# Altium schematic sheet 419-005-01-02_PG 11 - USBs.SchDoc("419-005-01-02_PG 11 - USBs")
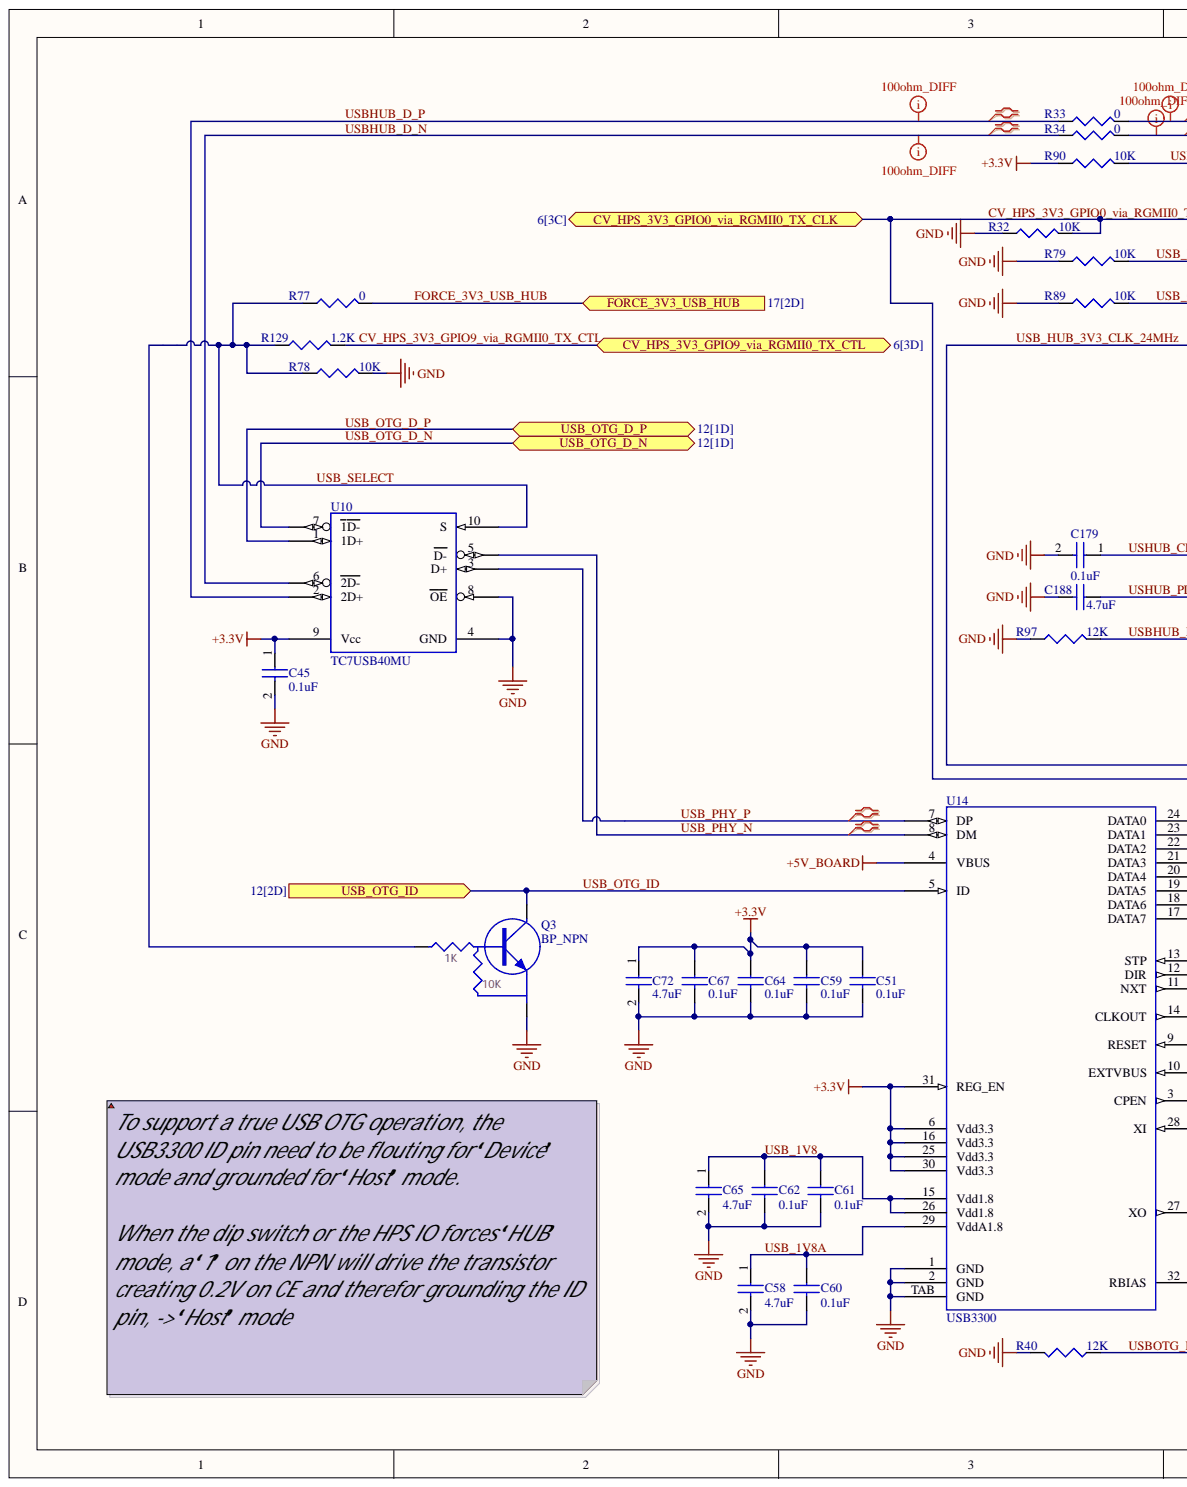
[diagram p0: 419-005-01-02_PG 11 - USBs.SchDoc, left]
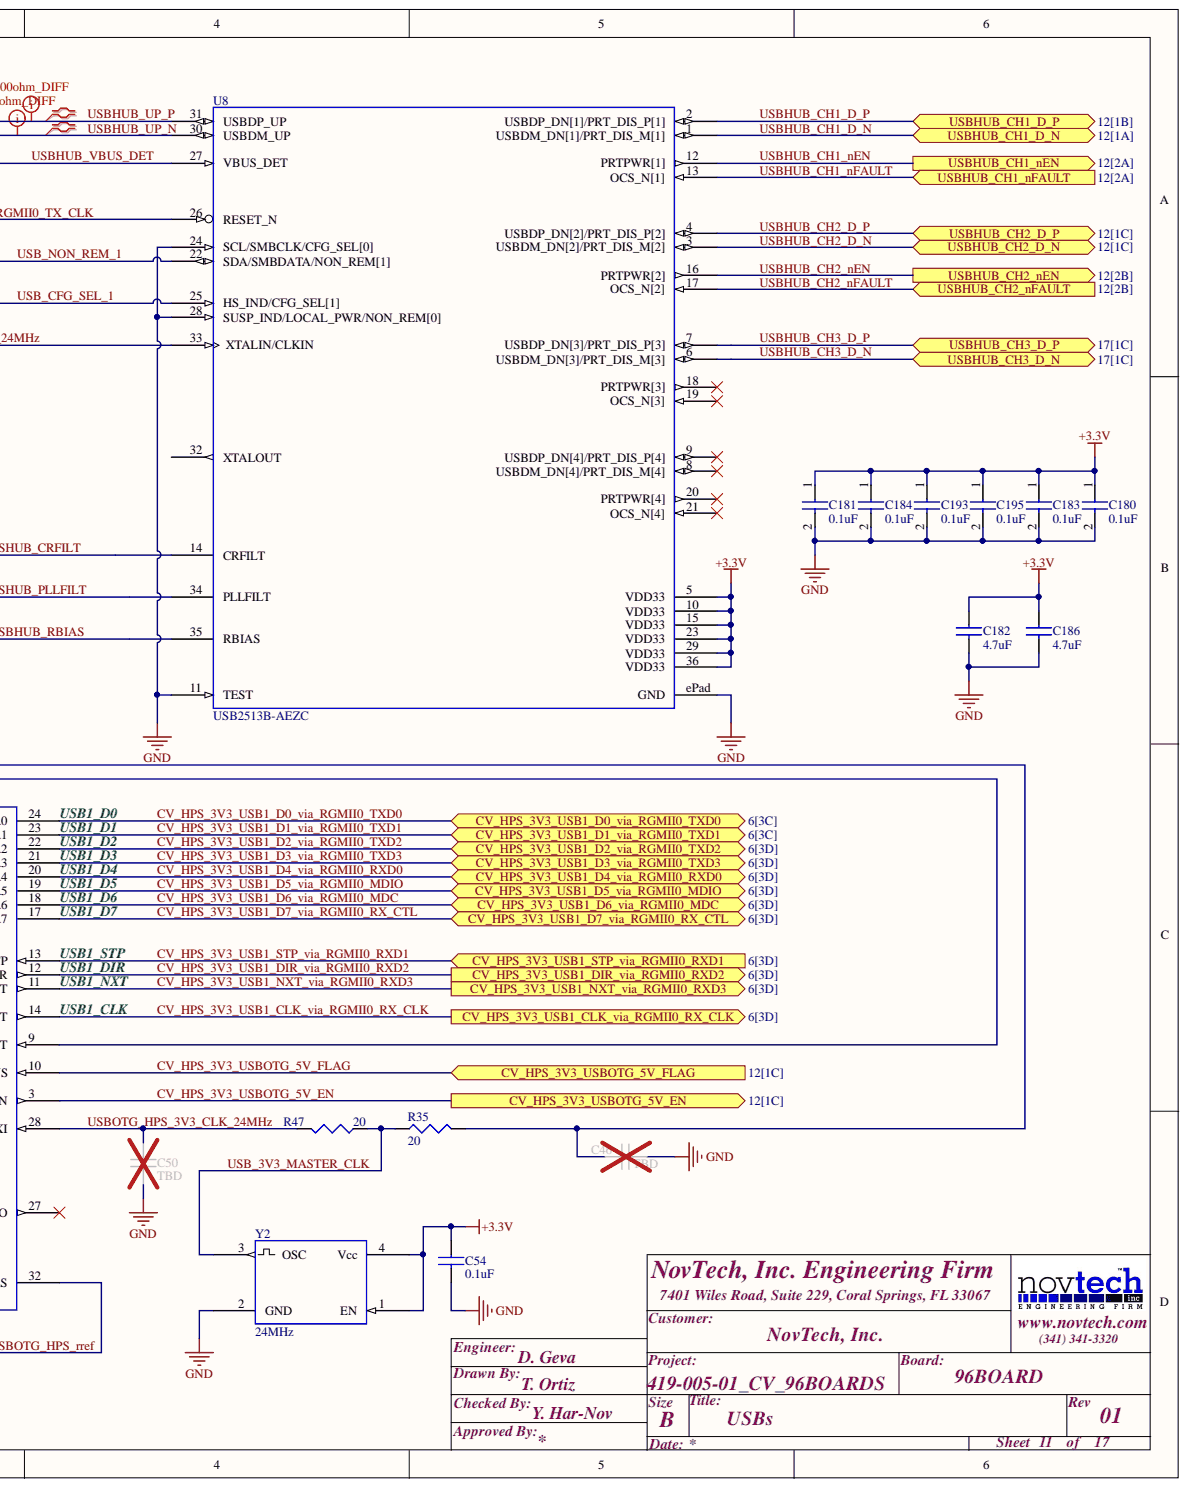
[diagram p1: 419-005-01-02_PG 11 - USBs.SchDoc, right]

## Parts
C45  0.1uF  [0201_C]
C46  TBD  [0402_C]
C50  TBD  [0402_C]
C51  0.1uF  [0201_C]
C54  0.1uF  [0201_C]
C58  4.7uF  [0402_C]
C59  0.1uF  [0201_C]
C60  0.1uF  [0201_C]
C61  0.1uF  [0201_C]
C62  0.1uF  [0201_C]
C64  0.1uF  [0201_C]
C65  4.7uF  [0402_C]
C67  0.1uF  [0201_C]
C72  4.7uF  [0402_C]
C179  0.1uF  [0201_C]
C180  0.1uF  [0201_C]
C181  0.1uF  [0201_C]
C182  4.7uF  [0402_C]
C183  0.1uF  [0201_C]
C184  0.1uF  [0201_C]
C186  4.7uF  [0402_C]
C188  4.7uF  [0402_C]
C193  0.1uF  [0201_C]
C195  0.1uF  [0201_C]
Q3  BP_NPN  [SOT23-NPN-PNP]
R32  10K  [0201_R]
R33  0  [0201_R]
R34  0  [0201_R]
R35  20  [0402_R]
R40  12K  [0402_R]
R47  20  [0402_R]
R77  0  [0201_R]
R78  10K  [0201_R]
R79  10K  [0201_R]
R89  10K  [0201_R]
R90  10K  [0201_R]
R97  12K  [0201_R]
R129  1.2K  [0201_R]
U8  USB2513B-AEZC  [QFN36_6X6]
U10  TC7USB40MU  [UQFN10_1.4X1.8]
U14  USB3300  [QFN32]
Y2  24MHz  [OSC_SMT_2.5X2.0]

## Ports
CV_HPS_3V3_GPIO0_VIA_RGMII0_TX_CLK, CV_HPS_3V3_GPIO9_VIA_RGMII0_TX_CTL, CV_HPS_3V3_USB1_CLK_VIA_RGMII0_RX_CLK, CV_HPS_3V3_USB1_D0_VIA_RGMII0_TXD0, CV_HPS_3V3_USB1_D1_VIA_RGMII0_TXD1, CV_HPS_3V3_USB1_D2_VIA_RGMII0_TXD2, CV_HPS_3V3_USB1_D3_VIA_RGMII0_TXD3, CV_HPS_3V3_USB1_D4_VIA_RGMII0_RXD0, CV_HPS_3V3_USB1_D5_VIA_RGMII0_MDIO, CV_HPS_3V3_USB1_D6_VIA_RGMII0_MDC, CV_HPS_3V3_USB1_D7_VIA_RGMII0_RX_CTL, CV_HPS_3V3_USB1_DIR_VIA_RGMII0_RXD2, CV_HPS_3V3_USB1_NXT_VIA_RGMII0_RXD3, CV_HPS_3V3_USB1_STP_VIA_RGMII0_RXD1, CV_HPS_3V3_USBOTG_5V_EN, CV_HPS_3V3_USBOTG_5V_FLAG, FORCE_3V3_USB_HUB, USB_OTG_D_N, USB_OTG_D_P, USB_OTG_ID, USBHUB_CH1_D_N, USBHUB_CH1_D_P, USBHUB_CH1_NEN, USBHUB_CH1_NFAULT, USBHUB_CH2_D_N, USBHUB_CH2_D_P, USBHUB_CH2_NEN, USBHUB_CH2_NFAULT, USBHUB_CH3_D_N, USBHUB_CH3_D_P

## Nets
+3.3V: C45.1 C51.1 C54.1 C59.1 C64.1 C67.1 C72.1 C180.1 C181.1 C182.1 C183.1 C184.1 C186.1 C193.1 C195.1 R90.1 U8.5(VDD33) U8.10(VDD33) U8.15(VDD33) U8.23(VDD33) U8.29(VDD33) U8.36(VDD33) U10.9(Vcc) U14.6(Vdd3.3) U14.16(Vdd3.3) U14.25(Vdd3.3) U14.30(Vdd3.3) U14.31(REG_EN) Y2.1(EN) Y2.4(Vcc)
+5V_BOARD: U14.4(VBUS)
GND: C45.2 C46.2 C50.2 C51.2 C54.2 C58.2 C59.2 C60.2 C61.2 C62.2 C64.2 C65.2 C67.2 C72.2 C179.2 C180.2 C181.2 C182.2 C183.2 C184.2 C186.2 C188.2 C193.2 C195.2 Q3.EMIT R32.1 R40.2 R78.2 R79.1 R89.1 R97.1 U8.11(TEST) U8.24(SCL/SMBCLK/CFG_SEL[0]) U8.28(SUSP_IND/LOCAL_PWR/NON_REM[0]) U8.ePad(GND) U10.4(GND) U10.8(OE) U14.1(GND) U14.2(GND) U14.TAB(GND) Y2.2(GND)
CV_HPS_3V3_GPIO0_VIA_RGMII0_TX_CLK: R32.2 U8.26(RESET_N) U14.9(RESET) ; port CV_HPS_3V3_GPIO0_VIA_RGMII0_TX_CLK
CV_HPS_3V3_GPIO9_VIA_RGMII0_TX_CTL: R129.2 ; port CV_HPS_3V3_GPIO9_VIA_RGMII0_TX_CTL
CV_HPS_3V3_USB1_CLK_VIA_RGMII0_RX_CLK: U14.14(CLKOUT) ; port CV_HPS_3V3_USB1_CLK_VIA_RGMII0_RX_CLK
CV_HPS_3V3_USB1_D0_VIA_RGMII0_TXD0: U14.24(DATA0) ; port CV_HPS_3V3_USB1_D0_VIA_RGMII0_TXD0
CV_HPS_3V3_USB1_D1_VIA_RGMII0_TXD1: U14.23(DATA1) ; port CV_HPS_3V3_USB1_D1_VIA_RGMII0_TXD1
CV_HPS_3V3_USB1_D2_VIA_RGMII0_TXD2: U14.22(DATA2) ; port CV_HPS_3V3_USB1_D2_VIA_RGMII0_TXD2
CV_HPS_3V3_USB1_D3_VIA_RGMII0_TXD3: U14.21(DATA3) ; port CV_HPS_3V3_USB1_D3_VIA_RGMII0_TXD3
CV_HPS_3V3_USB1_D4_VIA_RGMII0_RXD0: U14.20(DATA4) ; port CV_HPS_3V3_USB1_D4_VIA_RGMII0_RXD0
CV_HPS_3V3_USB1_D5_VIA_RGMII0_MDIO: U14.19(DATA5) ; port CV_HPS_3V3_USB1_D5_VIA_RGMII0_MDIO
CV_HPS_3V3_USB1_D6_VIA_RGMII0_MDC: U14.18(DATA6) ; port CV_HPS_3V3_USB1_D6_VIA_RGMII0_MDC
CV_HPS_3V3_USB1_D7_VIA_RGMII0_RX_CTL: U14.17(DATA7) ; port CV_HPS_3V3_USB1_D7_VIA_RGMII0_RX_CTL
CV_HPS_3V3_USB1_DIR_VIA_RGMII0_RXD2: U14.12(DIR) ; port CV_HPS_3V3_USB1_DIR_VIA_RGMII0_RXD2
CV_HPS_3V3_USB1_NXT_VIA_RGMII0_RXD3: U14.11(NXT) ; port CV_HPS_3V3_USB1_NXT_VIA_RGMII0_RXD3
CV_HPS_3V3_USB1_STP_VIA_RGMII0_RXD1: U14.13(STP) ; port CV_HPS_3V3_USB1_STP_VIA_RGMII0_RXD1
CV_HPS_3V3_USBOTG_5V_EN: U14.3(CPEN) ; port CV_HPS_3V3_USBOTG_5V_EN
CV_HPS_3V3_USBOTG_5V_FLAG: U14.10(EXTVBUS) ; port CV_HPS_3V3_USBOTG_5V_FLAG
FORCE_3V3_USB_HUB: R77.2 ; port FORCE_3V3_USB_HUB
USB_1V8: C61.1 C62.1 C65.1 U14.15(Vdd1.8) U14.26(Vdd1.8)
USB_1V8A: C58.1 C60.1 U14.29(VddA1.8)
USB_3V3_MASTER_CLK: R35.1 R47.1 Y2.3
USB_CFG_SEL_1: R89.2 U8.25(HS_IND/CFG_SEL[1])
USB_HUB_3V3_CLK_24MHZ: C46.1 R35.2 U8.33(XTALIN/CLKIN)
USB_NON_REM_1: R79.2 U8.22(SDA/SMBDATA/NON_REM[1])
USB_OTG_D_N: U10.7(1D-) ; port USB_OTG_D_N
USB_OTG_D_P: U10.1(1D+) ; port USB_OTG_D_P
USB_OTG_ID: Q3.COLL U14.5(ID) ; port USB_OTG_ID
USB_PHY_N: U10.5(D-) U14.8(DM)
USB_PHY_P: U10.3(D+) U14.7(DP)
USB_SELECT: Q3.BASE R77.1 R78.1 R129.1 U10.10(S)
USBHUB_CH1_D_N: U8.1(USBDM_DN[1]/PRT_DIS_M[1]) ; port USBHUB_CH1_D_N
USBHUB_CH1_D_P: U8.2(USBDP_DN[1]/PRT_DIS_P[1]) ; port USBHUB_CH1_D_P
USBHUB_CH1_NEN: U8.12(PRTPWR[1]) ; port USBHUB_CH1_NEN
USBHUB_CH1_NFAULT: U8.13(OCS_N[1]) ; port USBHUB_CH1_NFAULT
USBHUB_CH2_D_N: U8.3(USBDM_DN[2]/PRT_DIS_M[2]) ; port USBHUB_CH2_D_N
USBHUB_CH2_D_P: U8.4(USBDP_DN[2]/PRT_DIS_P[2]) ; port USBHUB_CH2_D_P
USBHUB_CH2_NEN: U8.16(PRTPWR[2]) ; port USBHUB_CH2_NEN
USBHUB_CH2_NFAULT: U8.17(OCS_N[2]) ; port USBHUB_CH2_NFAULT
USBHUB_CH3_D_N: U8.6(USBDM_DN[3]/PRT_DIS_M[3]) ; port USBHUB_CH3_D_N
USBHUB_CH3_D_P: U8.7(USBDP_DN[3]/PRT_DIS_P[3]) ; port USBHUB_CH3_D_P
USBHUB_D_N: R34.1 U10.6(2D-)
USBHUB_D_P: R33.1 U10.2(2D+)
USBHUB_RBIAS: R97.2 U8.35(RBIAS)
USBHUB_UP_N: R34.2 U8.30(USBDM_UP)
USBHUB_UP_P: R33.2 U8.31(USBDP_UP)
USBHUB_VBUS_DET: R90.2 U8.27(VBUS_DET)
USBOTG_HPS_3V3_CLK_24MHZ: C50.1 R47.2 U14.28(XI)
USBOTG_HPS_RREF: R40.1 U14.32(RBIAS)
USHUB_CRFILT: C179.1 U8.14(CRFILT)
USHUB_PLLFILT: C188.1 U8.34(PLLFILT)

Not connected: U8 8(USBDM_DN[4]/PRT_DIS_M[4]) 9(USBDP_DN[4]/PRT_DIS_P[4]) 18(PRTPWR[3]) 19(OCS_N[3]) 20(PRTPWR[4]) 21(OCS_N[4]) 32(XTALOUT); U14 27(XO)

## Library data of the parts
C45 C51 C54 C59-C62 C64 C67 C179-C181 C183 C184 C193 C195 = CAP_0201: Capasitor 0201 footprint; Component Kind: Standard; Library Name: PASSIVES.SchLib
C46 C50 C58 C65 C72 C182 C186 C188 = CAP_0402: Capasitor 0402 footprint; Bottom: 10; Right: 20; Component Kind: Standard; Library Name: PASSIVES.SchLib
Q3 = NPN_PreBIAS: PART: PDTD113ZK; MARKING: *7V; MFG: NXP; TEMP: -65°C to +150C°; TYPE: SMT; Component Kind: Standard; DigiKey: PDTD113ZK,115-ND; Library Name: PASSIVES.SchLib; RoHS: Yes
R32 R78 R79 R89 R90 = RESISOTR 0201: Resistor 0201 footprint; PART: ERJ-1GEF1002C; MFG: Panasonic; Component Kind: Standard; Digikey: P10.0KABCT-ND; Library Name: PASSIVES.SchLib; RoHS: Yes; TYPE: SMT
R33 R34 = RESISOTR 0201: Resistor 0201 footprint; MFG: Panasonic; Component Kind: Standard; Library Name: PASSIVES.SchLib; RoHS: Yes; TYPE: SMT
R35 R47 = RESISOTR 0402: Resistor 0402 footprint; PART: RC0402FR-0720RL; MFG: Yageo; TEMP: -55°C to +155°C; Component Kind: Standard; DigiKey: 311-20LRCT-ND; Library Name: PASSIVES.SchLib; RoHS: Yes; TYPE: SMT
R40 = RESISOTR 0402: Resistor 0402 footprint; Component Kind: Standard; Library Name: PASSIVES.SchLib
R77 R129 = RESISOTR 0201: Resistor 0201 footprint; PART: ERJ-1GEF1201C; MFG: Panasonic; Component Kind: Standard; Digikey: P1.20KABCT-ND; Library Name: PASSIVES.SchLib; RoHS: Yes; TYPE: SMT
R97 = RESISOTR 0201: Resistor 0201 footprint; Component Kind: Standard; Library Name: PASSIVES.SchLib; RoHS: Yes; TYPE: SMT
U8 = USB2514: IC USB 2.0 4PORT HUB CTLR 36-QFN; MFG: Microchip (SMCS); TEMP: 0° to +70°C; Component Kind: Standard; DigiKey: 638-1100-ND; Library Name: IC_SchLib.SCHLIB; PART: USB2513B-AEZC; RoHS: Yes; TYPE: SMT
U10 = TC7USB40MU: USB SWITCH SPDT DUAL; PART: TC7USB40MU,LF; MARKING: A; MFG: TOSHIBA; TEMP: -40°C to +85°C; Component Kind: Standard; DigiKey: TC7USB40MULFCT-ND; Library Name: IC_SchLib.SCHLIB; RoHS: Yes; TYPE: SMT
U14 = USB3300: Hi-Speed USB Host, Device or OTG PHY; PART: USB3300-EZK; MFG: SMCS; TEMP: -40°C to +85°C; Component Kind: Standard; DigiKey: 638-1034-ND; Library Name: IC_SchLib.SCHLIB; RoHS: Yes
Y2 = OSCILLATOR: PART: ASDMB-24.000MHZ-LC-T; MFG: Abracon; TEMP: -40°C to +85°C; Component Kind: Standard; DigiKey: 535-11728-2-ND; Library Name: PASSIVES.SchLib; RoHS: Yes; TYPE: SMT
